# Altium schematic sheet main.SchDoc
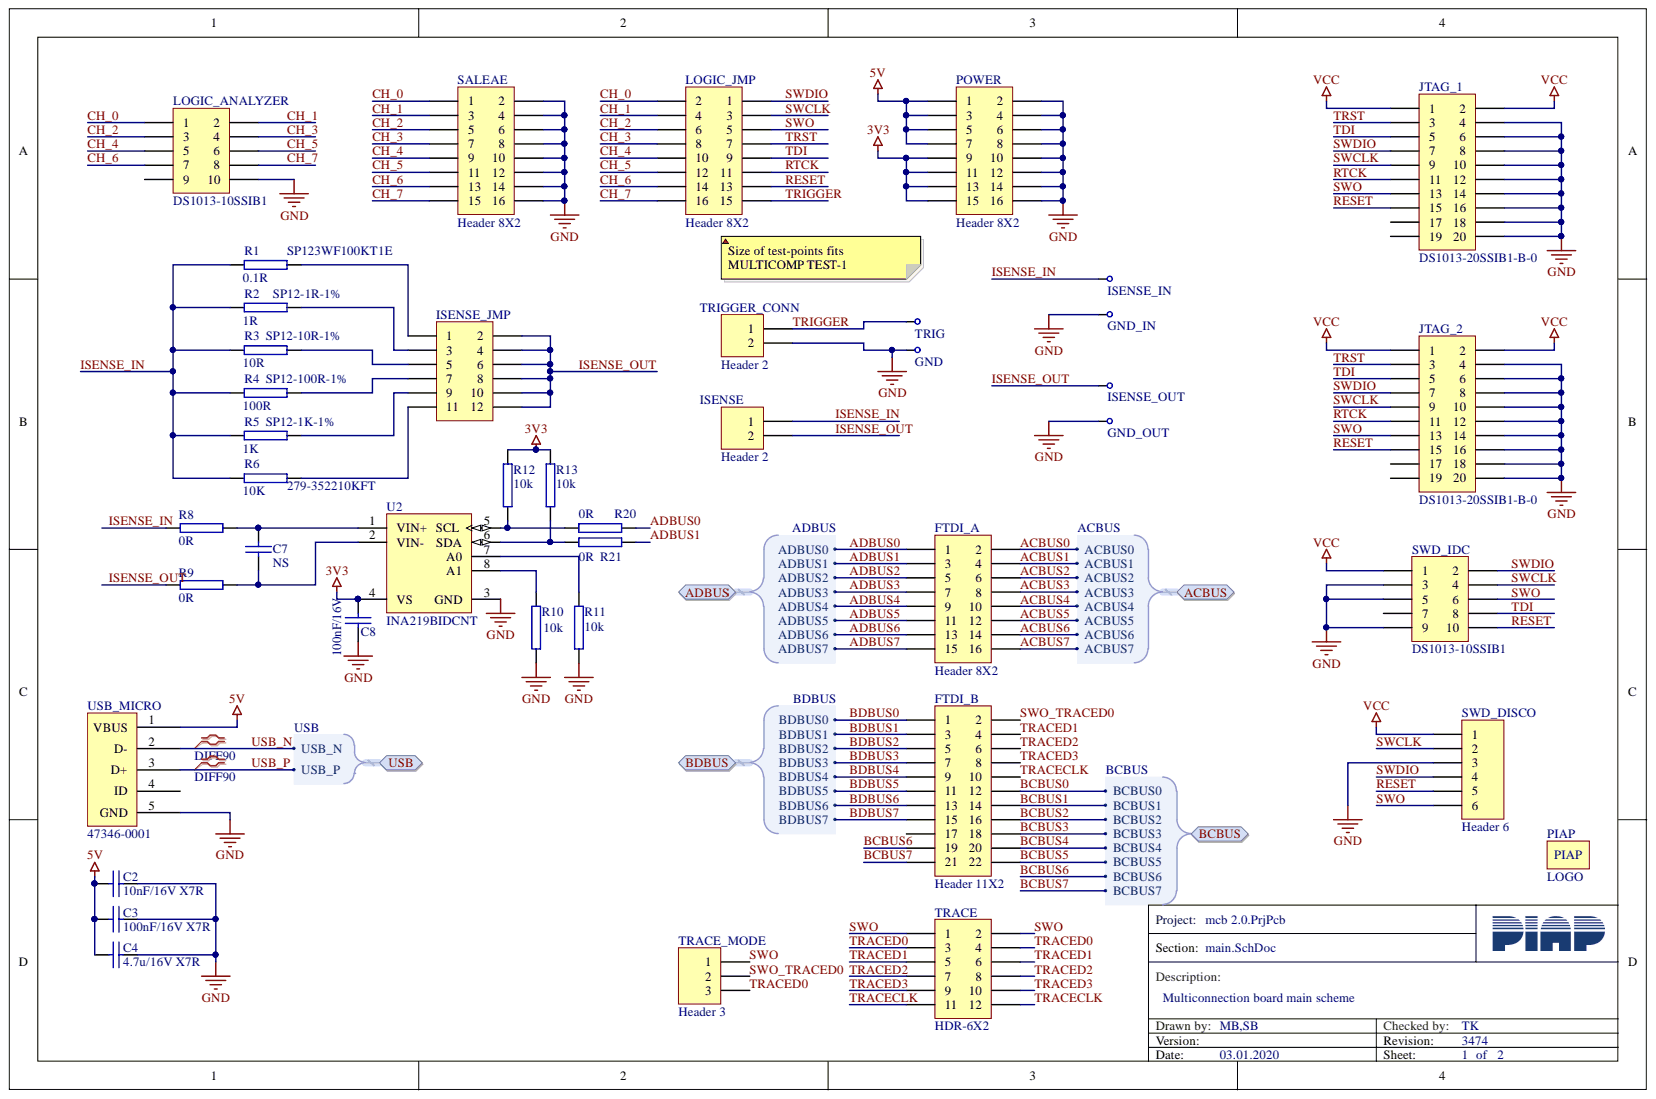
[diagram p0: main.SchDoc]

## Parts
C2  10nF/16V X7R  [0805]
C3  100nF/16V X7R  [0805]
C4  4.7u/16V X7R  [0805]
C7  NS  [0603]
C8  100nF/16V  [0402]
FTDI_A  Header 8X2  [HDR2X8]
FTDI_B  Header 11X2  [HDR2X11]
GND  TEST_POINT_THT  [TEST_POINT_THT]  (value not drawn)  (no BOM)
GND_IN  TEST_POINT_THT  [TEST_POINT_THT]  (value not drawn)  (no BOM)
GND_OUT  TEST_POINT_THT  [TEST_POINT_THT]  (value not drawn)  (no BOM)
ISENSE  Header 2  [HDR1X2]
ISENSE_IN  TEST_POINT_THT  [TEST_POINT_THT]  (value not drawn)  (no BOM)
ISENSE_JMP  (no value)  [HDR2X6]
ISENSE_OUT  TEST_POINT_THT  [TEST_POINT_THT]  (value not drawn)  (no BOM)
JTAG_1  DS1013-20SSIB1-B-0  [HDR2X10]
JTAG_2  DS1013-20SSIB1-B-0  [HDR2X10]
LOGIC_ANALYZER  DS1013-10SSIB1  [HDR2X5 socet]
LOGIC_JMP  Header 8X2  [HDR2X8]
PIAP  LOGO  [PIAP_5mm]  (no BOM)
POWER  Header 8X2  [HDR2X8]
R1  0.1R  Manufacturer Part Number: SP123WF100KT1E  [2512]
R2  1R  Supplier Part Number 1: SP12-1R-1%  [2512]
R3  10R  Supplier Part Number 1: SP12-10R-1%  [2512]
R4  100R  Supplier Part Number 1: SP12-100R-1%  [2512]
R5  1K  Supplier Part Number 1: SP12-1K-1%  [2512]
R6  10K  Supplier Part Number 3: 279-352210KFT  [2512]
R8  0R  [0603]
R9  0R  [0603]
R10  10k  [0603]
R11  10k  [0603]
R12  10k  [0603]
R13  10k  [0603]
R20  0R  [0603]
R21  0R  [0603]
SALEAE  Header 8X2  [HDR2X8]
SWD_DISCO  Header 6  [HDR1X6]
SWD_IDC  DS1013-10SSIB1  [HDR2X5 socet]
TRACE  HDR-6X2  [HDR2X6]
TRACE_MODE  Header 3  [HDR1X3]
TRIG  TEST_POINT_THT  [TEST_POINT_THT]  (value not drawn)  (no BOM)
TRIGGER_CONN  Header 2  [HDR1X2]
U2  INA219BIDCNT  [DCN0008A_M]
USB_MICRO  47346-0001  [47346-0001]

## Ports
ACBUS, ACBUS.ACBUS0, ACBUS.ACBUS1, ACBUS.ACBUS2, ACBUS.ACBUS3, ACBUS.ACBUS4, ACBUS.ACBUS5, ACBUS.ACBUS6, ACBUS.ACBUS7, ADBUS, ADBUS.ADBUS0, ADBUS.ADBUS1, ADBUS.ADBUS2, ADBUS.ADBUS3, ADBUS.ADBUS4, ADBUS.ADBUS5, ADBUS.ADBUS6, ADBUS.ADBUS7, BCBUS, BCBUS.BCBUS0, BCBUS.BCBUS1, BCBUS.BCBUS2, BCBUS.BCBUS3, BCBUS.BCBUS4, BCBUS.BCBUS5, BCBUS.BCBUS6, BCBUS.BCBUS7, BDBUS, BDBUS.BDBUS0, BDBUS.BDBUS1, BDBUS.BDBUS2, BDBUS.BDBUS3, BDBUS.BDBUS4, BDBUS.BDBUS5, BDBUS.BDBUS6, BDBUS.BDBUS7, USB, USB.USB_N, USB.USB_P

## Nets
3V3: C8.1 POWER.9 POWER.11 POWER.13 POWER.15 R12.2 R13.2 U2.4(VS)
5V: C2.2 C3.1 C4.2 POWER.1 POWER.3 POWER.5 POWER.7 USB_MICRO.1(VBUS)
GND: C2.1 C3.2 C4.1 C8.2 GND.1 GND_IN.1 GND_OUT.1 JTAG_1.4 JTAG_1.6 JTAG_1.8 JTAG_1.10 JTAG_1.12 JTAG_1.14 JTAG_1.16 JTAG_1.18 JTAG_1.20 JTAG_2.4 JTAG_2.6 JTAG_2.8 JTAG_2.10 JTAG_2.12 JTAG_2.14 JTAG_2.16 JTAG_2.18 JTAG_2.20 LOGIC_ANALYZER.10 POWER.2 POWER.4 POWER.6 POWER.8 POWER.10 POWER.12 POWER.14 POWER.16 R10.2 R11.2 SALEAE.2 SALEAE.4 SALEAE.6 SALEAE.8 SALEAE.10 SALEAE.12 SALEAE.14 SALEAE.16 SWD_DISCO.3 SWD_IDC.3 SWD_IDC.5 SWD_IDC.9 TRIGGER_CONN.2 U2.3(GND) USB_MICRO.5(GND)
VCC: JTAG_1.1 JTAG_1.2 JTAG_2.1 JTAG_2.2 SWD_DISCO.1 SWD_IDC.1
ACBUS0: FTDI_A.2 ; port ACBUS
ACBUS1: FTDI_A.4 ; port ACBUS
ACBUS2: FTDI_A.6 ; port ACBUS
ACBUS3: FTDI_A.8 ; port ACBUS
ACBUS4: FTDI_A.10 ; port ACBUS
ACBUS5: FTDI_A.12 ; port ACBUS
ACBUS6: FTDI_A.14 ; port ACBUS
ACBUS7: FTDI_A.16 ; port ACBUS
ADBUS0: FTDI_A.1 R20.1 ; port ADBUS
ADBUS1: FTDI_A.3 R21.1 ; port ADBUS
ADBUS2: FTDI_A.5 ; port ADBUS
ADBUS3: FTDI_A.7 ; port ADBUS
ADBUS4: FTDI_A.9 ; port ADBUS
ADBUS5: FTDI_A.11 ; port ADBUS
ADBUS6: FTDI_A.13 ; port ADBUS
ADBUS7: FTDI_A.15 ; port ADBUS
BCBUS0: FTDI_B.12 ; port BCBUS
BCBUS1: FTDI_B.14 ; port BCBUS
BCBUS2: FTDI_B.16 ; port BCBUS
BCBUS3: FTDI_B.18 ; port BCBUS
BCBUS4: FTDI_B.20 ; port BCBUS
BCBUS5: FTDI_B.22 ; port BCBUS
BCBUS6: FTDI_B.19 ; port BCBUS
BCBUS7: FTDI_B.21 ; port BCBUS
BDBUS0: FTDI_B.1 ; port BDBUS
BDBUS1: FTDI_B.3 ; port BDBUS
BDBUS2: FTDI_B.5 ; port BDBUS
BDBUS3: FTDI_B.7 ; port BDBUS
BDBUS4: FTDI_B.9 ; port BDBUS
BDBUS5: FTDI_B.11 ; port BDBUS
BDBUS6: FTDI_B.13 ; port BDBUS
BDBUS7: FTDI_B.15 ; port BDBUS
CH_0: LOGIC_ANALYZER.1 LOGIC_JMP.2 SALEAE.1
CH_1: LOGIC_ANALYZER.2 LOGIC_JMP.4 SALEAE.3
CH_2: LOGIC_ANALYZER.3 LOGIC_JMP.6 SALEAE.5
CH_3: LOGIC_ANALYZER.4 LOGIC_JMP.8 SALEAE.7
CH_4: LOGIC_ANALYZER.5 LOGIC_JMP.10 SALEAE.9
CH_5: LOGIC_ANALYZER.6 LOGIC_JMP.12 SALEAE.11
CH_6: LOGIC_ANALYZER.7 LOGIC_JMP.14 SALEAE.13
CH_7: LOGIC_ANALYZER.8 LOGIC_JMP.16 SALEAE.15
ISENSE_IN: ISENSE.1 ISENSE_IN.1 R1.1 R2.1 R3.1 R4.1 R5.1 R6.1 R8.2
ISENSE_OUT: ISENSE.2 ISENSE_JMP.2 ISENSE_JMP.4 ISENSE_JMP.6 ISENSE_JMP.8 ISENSE_JMP.10 ISENSE_JMP.12 ISENSE_OUT.1 R9.2
RESET: JTAG_1.15 JTAG_2.15 LOGIC_JMP.13 SWD_DISCO.5 SWD_IDC.10
RTCK: JTAG_1.11 JTAG_2.11 LOGIC_JMP.11
SWCLK: JTAG_1.9 JTAG_2.9 LOGIC_JMP.3 SWD_DISCO.2 SWD_IDC.4
SWDIO: JTAG_1.7 JTAG_2.7 LOGIC_JMP.1 SWD_DISCO.4 SWD_IDC.2
SWO: JTAG_1.13 JTAG_2.13 LOGIC_JMP.5 SWD_DISCO.6 SWD_IDC.6 TRACE.1 TRACE.2 TRACE_MODE.1
SWO_TRACED0: FTDI_B.2 TRACE_MODE.2
TDI: JTAG_1.5 JTAG_2.5 LOGIC_JMP.9 SWD_IDC.8
TRACECLK: FTDI_B.10 TRACE.11 TRACE.12
TRACED0: TRACE.3 TRACE.4 TRACE_MODE.3
TRACED1: FTDI_B.4 TRACE.5 TRACE.6
TRACED2: FTDI_B.6 TRACE.7 TRACE.8
TRACED3: FTDI_B.8 TRACE.9 TRACE.10
TRIGGER: LOGIC_JMP.15 TRIG.1 TRIGGER_CONN.1
TRST: JTAG_1.3 JTAG_2.3 LOGIC_JMP.7
USB_N: USB_MICRO.2(D-) ; port USB
USB_P: USB_MICRO.3(D+) ; port USB
NetC7_1: C7.1 R9.1 U2.2(VIN-)
NetC7_2: C7.2 R8.1 U2.1(VIN+)
NetISENSE_JMP_1: ISENSE_JMP.1 R1.2
NetISENSE_JMP_3: ISENSE_JMP.3 R2.2
NetISENSE_JMP_5: ISENSE_JMP.5 R3.2
NetISENSE_JMP_7: ISENSE_JMP.7 R4.2
NetISENSE_JMP_9: ISENSE_JMP.9 R5.2
NetISENSE_JMP_11: ISENSE_JMP.11 R6.2
NetR10_1: R10.1 U2.8(A1)
NetR11_1: R11.1 U2.7(A0)
NetR12_1: R12.1 R20.2 U2.5(SCL)
NetR13_1: R13.1 R21.2 U2.6(SDA)

Not connected: FTDI_B 17; JTAG_1 17 19; JTAG_2 17 19; LOGIC_ANALYZER 9; SWD_IDC 7; USB_MICRO 4(ID)

## Library data of the parts
C2 = n100: Chip Capacitor 10nF/16V X7R; Dostawca: Farnell; email: AGrabowska@farnell.com; Tel/Fax: +48 12 4424724; Component Kind: Standard; Library Name: capacitors.SchLib; Opis: Chip Capacitor; Value: 10nF/16V X7R
C3 = n100: Chip Capacitor 100nF/16V X7R; Dostawca: Farnell; email: AGrabowska@farnell.com; Tel/Fax: +48 12 4424724; Component Kind: Standard; Library Name: capacitors.SchLib; Opis: Chip Capacitor; Value: 100nF/16V X7R
C4 = n100: Chip Capacitor 4.7u/16V X7R; Dostawca: Farnell; email: AGrabowska@farnell.com; Tel/Fax: +48 12 4424724; Component Kind: Standard; Library Name: capacitors.SchLib; Opis: Chip Capacitor; Value: 4.7u/16V X7R
C7 = n100: Dostawca: Farnell; email: AGrabowska@farnell.com; Opis: Chip Capacitor; Tel/Fax: +48 12 4424724; Component Kind: Standard; Description: NS; Library Name: capacitors.SchLib; Value: NS
C8 = n100: NS; Dostawca: Farnell; email: AGrabowska@farnell.com; Opis: Chip Capacitor; Tel/Fax: +48 12 4424724; Component Kind: Standard; Library Name: capacitors.SchLib; Value: 100nF/16V
FTDI_A LOGIC_JMP POWER SALEAE = Header 8X2: Header, 16-Pin, Dual row, 2.54mm; Cena jednostkowa: 7,59; Dostawca: TME; Nr Katalogowy: 280385-2; Component Kind: Standard; Library Name: connectors.SchLib; Value: Header 8X2
FTDI_B = Header 11X2: Header, 22-Pin, Dual row, 2.54mm; Component Kind: Standard; Library Name: connectors.SchLib; Value: Header 11X2
ISENSE TRIGGER_CONN = Header 2: Header, 2-Pin,  2.54mm; Cena jednostkowa: 1,89; Dostawca: TME; Nr Katalogowy: 280370-2; Component Kind: Standard; Library Name: connectors.SchLib; Value: Header 2
ISENSE_JMP = Header 6X2: Header, 6-Pin, Dual row; Component Kind: Standard; LatestRevisionDate: 17-Jul-2002; LatestRevisionNote: Re-released for DXP Platform.; Library Name: Miscellaneous Connectors.IntLib; Signal Integrity: Connector; Supplier 1: Farnell; Supplier 2: Mouser; Supplier Part Number 1: 1022237; Supplier Part Number 2: 855-M20-9980645; Publisher: Altium Limited
JTAG_1 JTAG_2 = Header 10X2: Header, 20-Pin, Dual row, 2.54mm; Component Kind: Standard; Library Name: connectors.SchLib; Manufacturer Part Number: DS1013-20SSIB1-B-0; Pricing 1: 2=0.3333, 10=0.2271, 100=0.1514, 1000=0.1261, 3000=0.1009 (USD); Stock 1: 60169; Supplier 1: TME; Value: Header 10X2
LOGIC_ANALYZER SWD_IDC = Header 5X2: Header, 10-Pin, Dual row, 2.54mm; Dostawca: TME; Component Kind: Standard; Library Name: connectors.SchLib; Manufacturer Part Number: DS1013-10SSIB1; Pricing 1: 10=0.097, 50=0.0772, 200=0.0661, 1000=0.0551, 5000=0.0441 (USD); Stock 1: 66977; Supplier 1: TME; Value: Header 5X2
PIAP = LOGO: Logo PIAP; Library Name: no_bom.SchLib
R1 = 1R: Resistor 0.1R 3W 1%; Manufacturer: Royal Electronic Factory; Dokładność: 5%; Dostawca: Farnell; Component Kind: Standard; ComponentLink1Description: http://datasheet.octopart.com/SP123WF100KT1E-Royal-Ohm-datasheet-86661178.pdf; ComponentLink1URL: http://datasheet.octopart.com/SP123WF100KT1E-Royal-Ohm-datasheet-86661178.pdf; Library Name: resistors.SchLib; Pricing 1: 1=0.772, 10=0.363, 100=0.227, 1000=0.173, 4000=0.1545 (USD); Stock 1: 0; Supplier 1: Digi-Key; Supplier 2: Farnell; Supplier Part Number 1: A103632CT-ND; Supplier Part Number 2: 1086356; Value: 0.1R
R2 = 1R: Resistor 1R 3W 1%; Manufacturer: Royal Electronic Factory; Manufacturer Part Number: SP123WF100KT1E; Dostawca: Farnell; Component Kind: Standard; ComponentLink1Description: http://datasheet.octopart.com/SP123WF100KT1E-Royal-Ohm-datasheet-86661178.pdf; ComponentLink1URL: http://datasheet.octopart.com/SP123WF100KT1E-Royal-Ohm-datasheet-86661178.pdf; Dokładność: 1%; Library Name: resistors.SchLib; Pricing 1: 1=0.772, 10=0.363, 100=0.227, 1000=0.173, 4000=0.1545 (USD); Stock 1: 0; Supplier 1: TME; Value: 1R
R3 = 1R: Resistor 10R 3W 1%; Manufacturer: Royal Electronic Factory; Manufacturer Part Number: SP123WF100JT1E; Dostawca: Farnell; Component Kind: Standard; ComponentLink1Description: http://datasheet.octopart.com/SP123WF100JT1E-Royal-Ohm-datasheet-86661178.pdf; ComponentLink1URL: http://datasheet.octopart.com/SP123WF100JT1E-Royal-Ohm-datasheet-86661178.pdf; Dokładność: 1%; Library Name: resistors.SchLib; Pricing 1: 1=0.772, 10=0.363, 100=0.227, 1000=0.173, 4000=0.1545 (USD); Stock 1: 410; Supplier 1: TME; Value: 10R
R4 = 1R: Resistor 100R 2W 1%; Manufacturer: Royal Electronic Factory; Manufacturer Part Number: SP123WJ0101T1E; Dostawca: Farnell; Component Kind: Standard; ComponentLink1Description: http://datasheet.octopart.com/SP123WJ0101T1E-Royal-Ohm-datasheet-86661178.pdf; ComponentLink1URL: http://datasheet.octopart.com/SP123WJ0101T1E-Royal-Ohm-datasheet-86661178.pdf; Dokładność: 1%; Library Name: resistors.SchLib; Pricing 1: 5=0.315, 25=0.214, 100=0.134, 1000=0.102, 4000=0.0909 (USD); Stock 1: 1973; Supplier 1: TME; Value: 100R
R5 = 1R: Resistor 1k 2W 1%; Manufacturer: Royal Electronic Factory; Manufacturer Part Number: SP123WF1001T1E; Dostawca: Farnell; Component Kind: Standard; ComponentLink1Description: http://datasheet.octopart.com/SP123WF1001T1E-Royal-Ohm-datasheet-86661178.pdf; ComponentLink1URL: http://datasheet.octopart.com/SP123WF1001T1E-Royal-Ohm-datasheet-86661178.pdf; Dokładność: 1%; Library Name: resistors.SchLib; Pricing 1: 1=0.772, 10=0.363, 100=0.227, 1000=0.173, 4000=0.1545 (USD); Stock 1: 718; Supplier 1: TME; Value: 1K
R6 = 1R: Resistor 10K 3W 1%; Manufacturer: Royal Electronic Factory; Manufacturer Part Number: SP123WF100KT1E; Dostawca: Farnell; Component Kind: Standard; ComponentLink1Description: http://datasheet.octopart.com/SP123WF100KT1E-Royal-Ohm-datasheet-86661178.pdf; ComponentLink1URL: http://datasheet.octopart.com/SP123WF100KT1E-Royal-Ohm-datasheet-86661178.pdf; Dokładność: 1%; Library Name: resistors.SchLib; Pricing 1: 1=0.772, 10=0.363, 100=0.227, 1000=0.173, 4000=0.1545 (USD); Stock 1: 0; Supplier 1: Digi-Key; Supplier 2: Farnell; Supplier 3: Mouser; Supplier Part Number 1: A121120CT-ND; Supplier Part Number 2: 2476512; Value: 10K
R8 R10-R13 R20 R21 = k100: Chip Resistor 0Ohm 0.25W; Dokładność: 1%; Dostawca: Farnell; ID: CRCW0805100KFKEA; Nr Katalogowy: 1469860; Component Kind: Standard; HelpURL: http://www.vishay.com/docs/20035/dcrcwe3.pdf; Library Name: resistors.SchLib
  R8 R20 R21: Value: 0R
  R10-R13: Value: 10k
R9 = k100: Chip Resistor 0 Ohm 0.25W; Dokładność: 1%; Dostawca: Farnell; ID: CRCW0805100KFKEA; Nr Katalogowy: 1469860; Component Kind: Standard; HelpURL: http://www.vishay.com/docs/20035/dcrcwe3.pdf; Library Name: resistors.SchLib; Value: 0R
SWD_DISCO = Header 6: Header, 6-Pin, 2.54mm; Cena jednostkowa: 0,33; Dostawca: TME; Nr Katalogowy: ZL262-6SG; Component Kind: Standard; Library Name: connectors.SchLib; Value: Header 6
TRACE = Header 6x2: Header, 12-Pin, Dual row, SMD, 2.54mm; Component Kind: Standard; Library Name: connectors.SchLib; Value: HDR-6X2
TRACE_MODE = Header 3: Header, 3-Pin,  2.54mm; Component Kind: Standard; Library Name: connectors.SchLib; Value: Header 3
U2 = CMP-0704-00042-2: Zero-Drift, Bi-Directional Current / Power Monitor, 3 to 5.5 V, -40 to 125 degC, 8-pin SOP (DCN8), Green (RoHS & no Sb/Br); Manufacturer: Texas Instruments; CMRR(Min)(dB): 100; Common Mode Range(Max)(V): 26; Common Mode Range(Min)(V): 0; Gain(V/V): 1, 0.5, 0.25, 0.125; Input Offset (+/-)(Max)(uV): 50; Quiescent Current (+/-)(Typ)(mA): 0.7; Rating: Catalog; Small-Signal Bandwidth(Typ)(MHz): 0.011; SubFamily: Current Shunt Monitor; Vs(Max)(V): 5.5; Vs(Min)(V): 3; Code_JEDEC: MO-178; Component Kind: Standard; ComponentLink1Description: Manufacturer URL; ComponentLink1URL: http://www.ti.com/; ComponentLink2Description: Datasheet; ComponentLink2URL: http://www.ti.com/general/docs/lit/getliterature.tsp?genericPartNumber=INA219&fileType=pdf; ComponentLink3Description: Package Specification; ComponentLink3URL: http://www.ti.com/lit/ml/mpds099c/mpds099c.pdf; DatasheetVersion: SBOS448F; Eco-Plan: Green (RoHS & no Sb/Br); Library Name: Altium Content Vault; Mounting Technology: Surface Mount; PackageDescription: 8-Pin Plastic Small Outline, Body 1.6 x 2.9 mm, Pitch 0.65 mm; PackageReference: DCN0008A; PackageVersion: 4202106/D, 11/2009; PartNumber: INA219BIDCNT; Supplier 1: Mouser; Supplier Part Number 1: 595-INA219BIDCNT
GND GND_IN GND_OUT ISENSE_IN ISENSE_OUT TRIG = TEST_POINT_THT: Library Name: no_bom.SchLib
USB_MICRO = 47346-0001: Component Kind: Standard; Library Name: connectors.SchLib
